# Altium schematic sheet Pulser.SchDoc(Pulser)
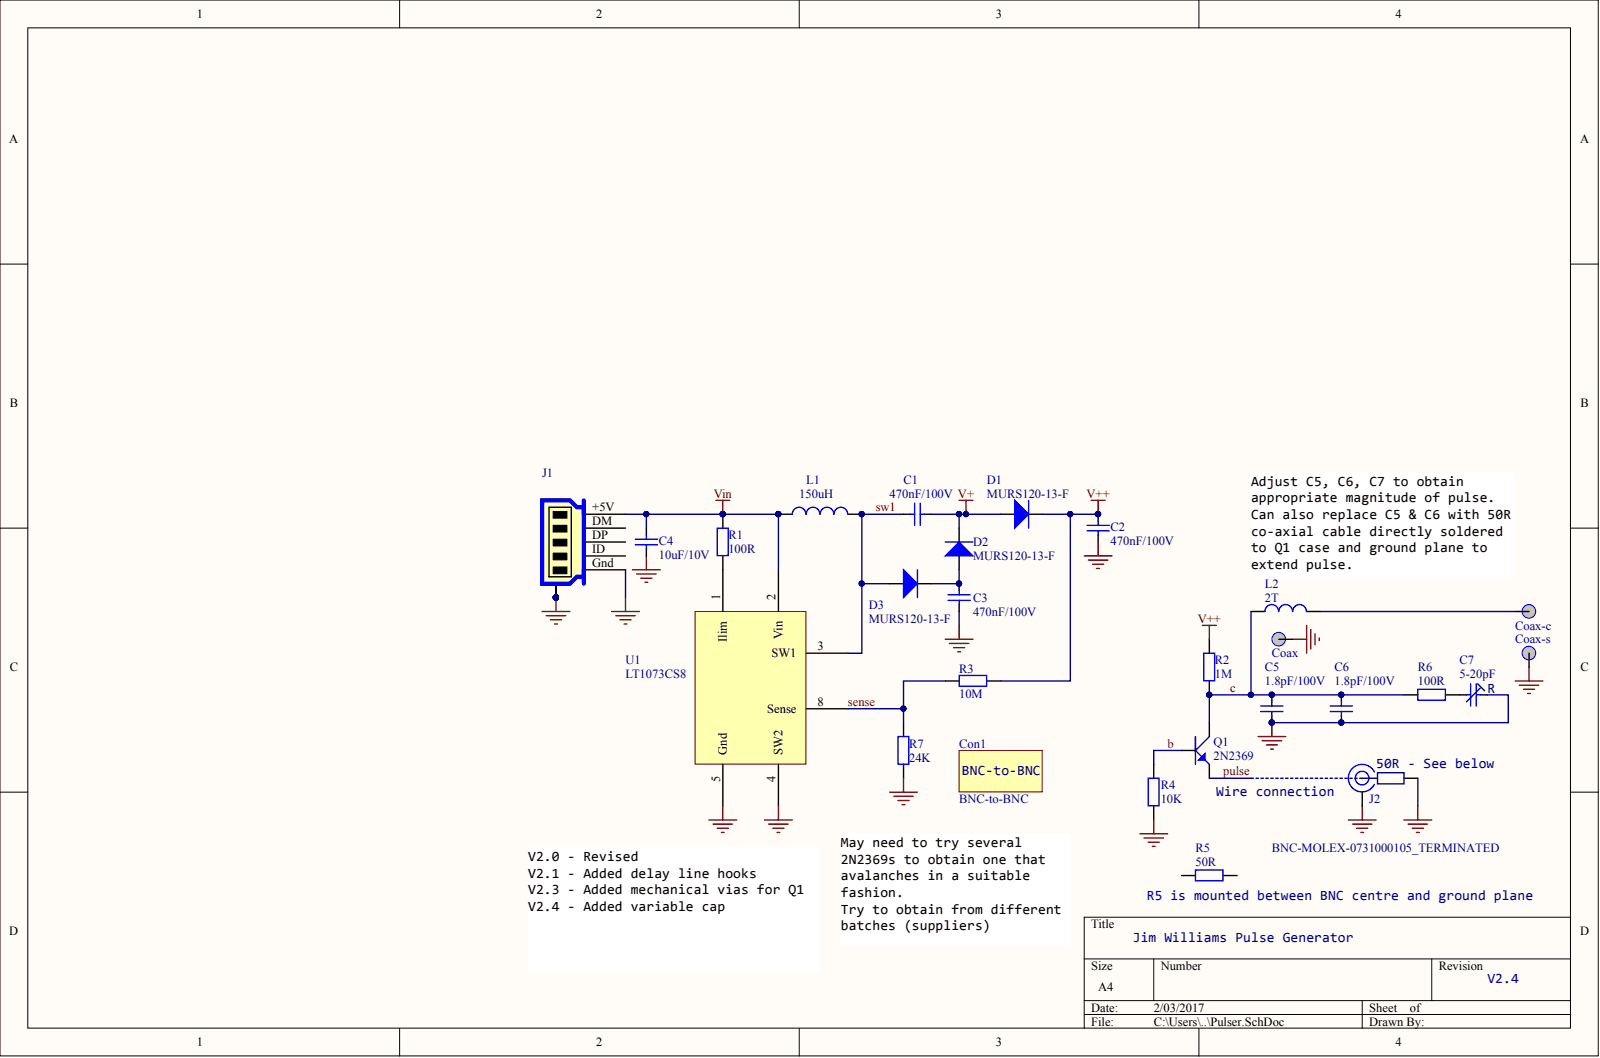
[diagram p0: Pulser.SchDoc]

## Parts
C1  470nF/100V  [CCNP3216-1206]
C2  470nF/100V  [CCNP3216-1206]
C3  470nF/100V  [CCNP3216-1206]
C4  10uF/10V  [CCNP2012-0805]
C5  1.8pF/100V  [CCNP2012-0805]
C6  1.8pF/100V  [CCNP2012-0805]
C7  5-20pF  [CAP_TRIM_SMT_TZC3]
Coax  PAD  [Pad]  (value not drawn)  (no BOM)
Coax-c  PAD  [Pad]  (value not drawn)  (no BOM)
Coax-s  PAD  [Pad]  (value not drawn)  (no BOM)
Con1  BNC-to-BNC  [BNC-to-BNC]  (mechanical)
D1  MURS120-13-F  [SMB_Diode]
D2  MURS120-13-F  [SMB_Diode]
D3  MURS120-13-F  [SMB_Diode]
J1  USB_MICRO_B_CONNECTOR  [MOLEX - 47346-0001]  (value not drawn)
J2  BNC-MOLEX-0731000105_TERMINATED  [BNC_RA CON - Molex - Resistor]
L1  150uH  [INDUCTOR_SMT]
L2  2T  [CL2012-0805]
Q1  2N2369  [TO-18-flush]
R1  100R  [CR2012-0805]
R2  1M  [CR2012-0805]
R3  10M  [CR2012-0805]
R4  10K  [CR2012-0805]
R5  50R  [CR3216-1206]  (mechanical)
R6  100R  [CR2012-0805]
R7  24K  [CR2012-0805]
U1  LT1073CS8  [SO-8_L]

## Nets
GND: C2.2 C3.1 C4.2 C5.1 C6.1 C7.R Coax.1 Coax-s.1 J1.G J1.S1 J1.S2 J1.S3 J1.S4 J1.S5 J1.S6 J2.1 J2.2 R4.1 R7.1 U1.4(SW2) U1.5(Gnd)
V+: C1.2 D1.A D2.K
V++: C2.1 D1.K R2.1 R3.2
VIN: C4.1 J1.VBUS L1.1 R1.1 U1.2(Vin)
B: Q1.B R4.2
C: C5.2 C6.2 L2.1 Q1.C R2.2 R6.1
PULSE: Q1.E
SENSE: R3.1 R7.2 U1.8(Sense)
SW1: C1.1 D3.A L1.2 U1.3(SW1)
NetC3_2: C3.2 D2.A D3.K
NetC7_S: C7.S R6.2
NetCoax-c_1: Coax-c.1 L2.2
NetR1_2: R1.2 U1.1(Ilim)

Not connected: J1 D+ D- ID; R5 1 2

## Library data of the parts
C1-C3 C5 C6 = Cap: Capacitor; Manufacturer: Generic Components; Class: Passive; Component Kind: Standard; Library Name: MyLibrary.IntLib; Published: 08/06/2000; Signal Integrity: Cap; Simulation: CAP; SubClass: Capacitor; Publisher: Altium Hobart Technology Centre
  C1-C3: Farnell PN: 2497173; Value: 470nF/100V
  C5 C6: Farnell PN: 2672812; Value: 1.8pF/100V
C4 = Cap_CCNP_0805: Capacitor SMT 0805; Manufacturer: Generic Components; Class: Passive; Component Kind: Standard; Library Name: MyLibrary.IntLib; Published: 08/06/2000; Signal Integrity: Cap; Simulation: CAP; SubClass: Capacitor; Value: 10uF/10V; Publisher: Altium Hobart Technology Centre
C7 = Cap_Trimmer_TZC3: Trimmer Capacitor muRata TZC3 series; Manufacturer: Generic Components; Class: Passive; Component Kind: Standard; Farnell PN: 9528652; Library Name: MySchLib.schlib; Published: 08/06/2000; Signal Integrity: Cap; Simulation: CAP; SubClass: Capacitor; Value: 5-20pF; Publisher: Altium Hobart Technology Centre
D1-D3 = MURS120-13-F: Fast / Ultrafast Diode, 200 V, 1 A; Manufacturer: Generic Components; Class: Discrete; SubClass: Diode - Switching; Component Kind: Standard; Farnell PN: 1858618; Library Name: MySchLib.schlib; Published: 08/06/2000; Publisher: Altium Hobart Technology Centre
J1 = USB_MICRO_B_CONNECTOR: USB Micro-B Connector; Component Kind: Standard; Farnell PN: 1568026; Library Name: MyLibrary.IntLib
J2 = BNC-MOLEX-0731000105_TERMINATED: BNC Connector; Component Kind: Standard; Digikey: WM5524-ND; Farnell PN: 1418237; Library Name: MyLibrary.IntLib
L1 = INDUCTOR-SMT: Inductor - SMT 3mm; Component Kind: Standard; Farnell PN: 1864148; Library Name: MySchLib.schlib; Simulation: Simulation; Value: 150uH
L2 = Ind_CL0805: Resistor; Manufacturer: Generic Components; Class: Passive; Component Kind: Standard; Library Name: MyLibrary.IntLib; Published: 08/06/2000; SubClass: Resistor; Value: 2T; Publisher: Altium Hobart Technology Centre
Q1 = 2N2369: High Speed Switching Transistor; Component Kind: Standard; Farnell PN: 9206850; Library Name: MySchLib.schlib
R1-R7 = Res: Resistor; Manufacturer: Generic Components; Class: Passive; Library Name: MyLibrary.IntLib; Published: 08/06/2000; Signal Integrity: Res; Simulation: RESISTOR; SubClass: Resistor; Publisher: Altium Hobart Technology Centre
  R1 R6: Component Kind: Standard; Value: 100R
  R2: Component Kind: Standard; Value: 1M
  R3: Component Kind: Standard; Value: 10M
  R4: Component Kind: Standard; Value: 10K
  R5: Value: 50R
  R7: Component Kind: Standard; Value: 24K
U1 = LT1073CS8: Micropower DC/DC Converter (Adjustable, 212mV reference); Component Kind: Standard; Farnell PN: 1273663; Library Name: MySchLib.schlib
Coax Coax-c Coax-s = PAD: Library Name: MyLibrary.IntLib
Con1 = BNC-to-BNC: Farnell PN: 4195255; Library Name: MySchLib.schlib
